# Altium schematic sheet Ethernet.SchDoc(Ethernet)
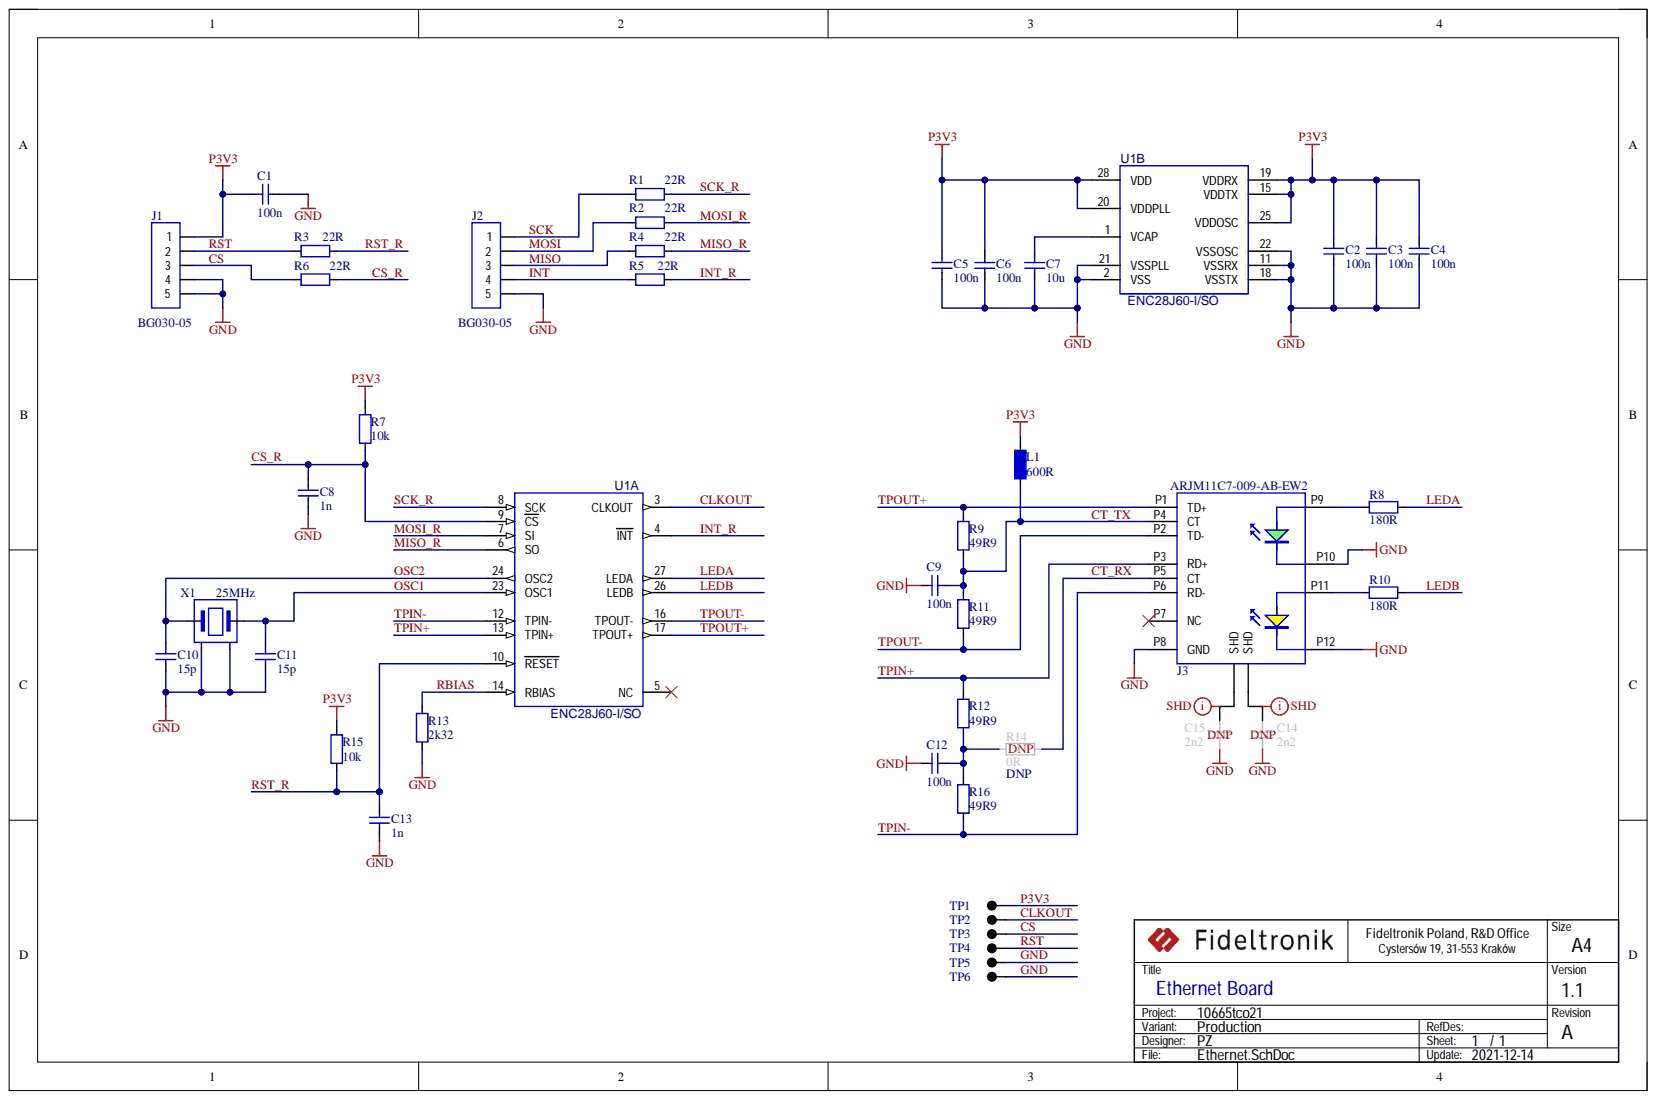
[diagram p0: Ethernet.SchDoc]

## Parts
C1  100n  [CAP_0603_R]
C2  100n  [CAP_0603_R]
C3  100n  [CAP_0603_R]
C4  100n  [CAP_0603_R]
C5  100n  [CAP_0603_R]
C6  100n  [CAP_0603_R]
C7  10u  [CAP_0603_R]
C8  1n  [CAP_0603_R]
C9  100n  [CAP_0603_R]
C10  15p  [CAP_0603_R]
C11  15p  [CAP_0603_R]
C12  100n  [CAP_0603_R]
C13  1n  [CAP_0603_R]
C14  2n2  [CAP_1812_H150_R]
C15  2n2  [CAP_1812_H150_R]
J1  BG030-05  [CON_HEADER_TMV_1X5_P254H704]
J2  BG030-05  [CON_HEADER_TMV_1X5_P254H704]
J3  ARJM11C7-009-AB-EW2  [CON_ABR_ARJM11C7-009-AB-EW2]
L1  600R  [IND_0603_R]
R1  22R  [RES_0402_R]
R2  22R  [RES_0402_R]
R3  22R  [RES_0402_R]
R4  22R  [RES_0402_R]
R5  22R  [RES_0402_R]
R6  22R  [RES_0402_R]
R7  10k  [RES_0603_R]
R8  180R  [RES_0603_R]
R9  49R9  [RES_0603_R]
R10  180R  [RES_0603_R]
R11  49R9  [RES_0603_R]
R12  49R9  [RES_0603_R]
R13  2k32  [RES_0603_R]
R14  0R  [RES_0603_R]
R15  10k  [RES_0603_R]
R16  49R9  [RES_0603_R]
TP1  PCB_TP_ICT  [PCB_TP_ICT_100]  (value not drawn)  (no BOM)
TP2  PCB_TP_ICT  [PCB_TP_ICT_100]  (value not drawn)  (no BOM)
TP3  PCB_TP_ICT  [PCB_TP_ICT_100]  (value not drawn)  (no BOM)
TP4  PCB_TP_ICT  [PCB_TP_ICT_100]  (value not drawn)  (no BOM)
TP5  PCB_TP_ICT  [PCB_TP_ICT_100]  (value not drawn)  (no BOM)
TP6  PCB_TP_ICT  [PCB_TP_ICT_100]  (value not drawn)  (no BOM)
U1  ENC28J60-I/SO  [PKG_SOP28_WIDE_R]  (parts A,B)
X1  25MHz  [OSC_EPS_TSX-3225_R]

## Nets
CLKOUT: TP2.1 U1.3(CLKOUT)
CS: J1.3 R6.1 TP3.1
CS_R: C8.2 R6.2 R7.2 U1.9(CS)
CT_RX: J3.P5(CT) R14.2
CT_TX: C9.2 J3.P4(CT) L1.1 R9.1 R11.1
GND: C1.1 C2.1 C3.1 C4.1 C5.1 C6.1 C7.1 C8.1 C9.1 C10.1 C11.1 C12.1 C13.1 C14.1 C15.1 J1.4 J1.5 J2.5 J3.P8(GND) J3.P10 J3.P12 R13.1 TP5.1 TP6.1 U1.2(VSS) U1.11(VSSRX) U1.18(VSSTX) U1.21(VSSPLL) U1.22(VSSOSC) X1.2 X1.4
INT: J2.4 R5.1
INT_R: R5.2 U1.4(INT)
LEDA: R8.2 U1.27(LEDA)
LEDB: R10.2 U1.26(LEDB)
MISO: J2.3 R4.1
MISO_R: R4.2 U1.6(SO)
MOSI: J2.2 R2.1
MOSI_R: R2.2 U1.7(SI)
OSC1: C11.2 U1.23(OSC1) X1.1
OSC2: C10.2 U1.24(OSC2) X1.3
P3V3: C1.2 C2.2 C3.2 C4.2 C5.2 C6.2 J1.1 L1.2 R7.1 R15.1 TP1.1 U1.15(VDDTX) U1.19(VDDRX) U1.20(VDDPLL) U1.25(VDDOSC) U1.28(VDD)
RBIAS: R13.2 U1.14(RBIAS)
RST: J1.2 R3.1 TP4.1
RST_R: C13.2 R3.2 R15.2 U1.10(RESET)
SCK: J2.1 R1.1
SCK_R: R1.2 U1.8(SCK)
TPIN+: J3.P3(RD+) R12.2 U1.13(TPIN+)
TPIN-: J3.P6(RD-) R16.2 U1.12(TPIN-)
TPOUT+: J3.P1(TD+) R9.2 U1.17(TPOUT+)
TPOUT-: J3.P2(TD-) R11.2 U1.16(TPOUT-)
NetC7_2: C7.2 U1.1(VCAP)
NetC12_2: C12.2 R12.1 R14.1 R16.1
NetC14_2: C14.2 J3.SH1(SHD)
NetC15_2: C15.2 J3.SH2(SHD)
NetJ3_P9: J3.P9 R8.1
NetJ3_P11: J3.P11 R10.1

Not connected: J3 P7(NC); U1 5(NC)

## Library data of the parts
C1-C6 C9 C12 = FT_C00015: CAP SMD 100n 10% 50V X7R (0603); Manufacturer: AVX; Manufacturer Part Number: 06035C104KAT2A; Database Table Name: CAPACITOR$; EBS: M1FT10130; SCH SYMBOL STATUS: Checked; Capacitance: 100n; Case: 0603; Component Kind: Standard; Dielectric: X7R; ITEM: FT_C00015; Library Name: FT_DB_LOCAL.DbLib; Mounting: S; STATUS: 2; Tolerance: 10%; Voltage: 50V
C7 = FT_C00371: CAP SMD 10u 10% 10V X5R (0603); Manufacturer: AVX; Manufacturer Part Number: 0603ZD106KAT2A; Database Table Name: CAPACITOR$; EBS: M1FT13760; SCH SYMBOL STATUS: Checked; Capacitance: 10u; Case: 0603; Component Kind: Standard; Dielectric: X5R; ITEM: FT_C00371; Library Name: FT_DB_LOCAL.DbLib; Mounting: S; STATUS: 0; Tolerance: 10%; Voltage: 10V
C8 C13 = FT_C00148: CAP SMD 1n 5% 50V C0G (0603); Manufacturer: AVX; Manufacturer Part Number: 06035A102JAT2A; Database Table Name: CAPACITOR$; EBS: M1FT11460; SCH SYMBOL STATUS: Checked; Capacitance: 1n; Case: 0603; Component Kind: Standard; Dielectric: C0G; ITEM: FT_C00148; Library Name: FT_DB_LOCAL.DbLib; Mounting: S; STATUS: 2; Tolerance: 5%; Voltage: 50V
C10 C11 = FT_C00084: CAP SMD 15p 5% 50V C0G (0603); Manufacturer: AVX; Manufacturer Part Number: 06035A150JAT2A; Database Table Name: CAPACITOR$; EBS: M1FT10820; SCH SYMBOL STATUS: Checked; Capacitance: 15p; Case: 0603; Component Kind: Standard; Dielectric: C0G; ITEM: FT_C00084; Library Name: FT_DB_LOCAL.DbLib; Mounting: S; STATUS: 2; Tolerance: 5%; Voltage: 50V
C14 C15 = FT_C00189: CAP SMD 2n2 10% 2000V X7R (1812); Manufacturer: AVX; Manufacturer Part Number: 1812GC222KAT1A; Database Table Name: CAPACITOR$; EBS: M1FT11880; SCH SYMBOL STATUS: Checked; Capacitance: 2n2; Case: 1812; Component Kind: Standard; Dielectric: X7R; ITEM: FT_C00189; Library Name: FT_DB_LOCAL.DbLib; Mounting: S; STATUS: 0; Tolerance: 10%; Voltage: 2000V
J1 J2 = FT_J00189: CONN THT / BOARD TO BOARD / PITCH 2,54mm / Vertical / Single Row / MALE / HEADER (1x5 PIN); Manufacturer: GCT; Manufacturer Part Number: BG030-05-A-0450-0300-N-G; Database Table Name: CONNECTOR$; Pitch (mm): 2,54; SCH SYMBOL STATUS: Checked; Component Kind: Standard; ITEM: FT_J00189; Library Name: FT_DB_LOCAL.DbLib; Mounting: T; Orientation: Vertical; Pins: 1x5; Properties: HEADER; Rows: Single Row; Side: MALE; STATUS: 0; Type: BOARD TO BOARD
J3 = FT_J00182: CONN THT / RJ-45 / Ethernet Connectors JACK W/MAG 1X1 100BASE-T; Manufacturer: ABRACON; Database Table Name: CONNECTOR$; SCH SYMBOL STATUS: new; Component Kind: Standard; ITEM: FT_J00182; Library Name: FT_DB_LOCAL.DbLib; Manufacturer Part Number: ARJM11C7-009-AB-EW2; Mounting: T; Properties: Ethernet Connectors JACK W/MAG 1X1 100BASE-T; STATUS: 0; Type: RJ-45
L1 = FT_F00025: FILTER SMD / FERRITE BEAD / 600 UM 100 MHz 200OHM 1GHz 500mA; Manufacturer: MURATA; Manufacturer Part Number: BLM18AG601SN1D; Database Table Name: FILTER$; SCH SYMBOL STATUS: Checked; Case: 0603; Component Kind: Standard; ITEM: FT_F00025; Library Name: FT_DB_LOCAL.DbLib; Mounting: S; Properties: 600 UM 100 MHz 200OHM 1GHz 500mA; STATUS: 0; Type: FERRITE BEAD
R1-R6 = FT_R00713: RES SMD 22R 1% 0.063W 100PPM (0402); Manufacturer: YAGEO; Manufacturer Part Number: RC0402FR-0722RL; Database Table Name: RESISTOR$; EBS: M1FT07160; SCH SYMBOL STATUS: Checked; Case: 0402; Component Kind: Standard; ITEM: FT_R00713; Library Name: FT_DB_LOCAL.DbLib; Mounting: S; Power: 0.063W; PPM: 100PPM; Resistance: 22R; STATUS: 0; Tolerance: 1%
R7 R15 = FT_R00098: RES SMD 10k 1% 0.1W 100PPM (0603); Manufacturer: ASJ; Manufacturer Part Number: CR16-1002-FL; Database Table Name: RESISTOR$; EBS: M1FT00970; SCH SYMBOL STATUS: Checked; Case: 0603; Component Kind: Standard; ITEM: FT_R00098; Library Name: FT_DB_LOCAL.DbLib; Mounting: S; Power: 0.1W; PPM: 100PPM; Resistance: 10k; STATUS: 2; Tolerance: 1%
R8 R10 = FT_R00236: RES SMD 180R 1% 0.1W 100PPM (0603); Manufacturer: ASJ; Manufacturer Part Number: CR16-1800-FL; Database Table Name: RESISTOR$; EBS: M1FT02350; SCH SYMBOL STATUS: Checked; Case: 0603; Component Kind: Standard; ITEM: FT_R00236; Library Name: FT_DB_LOCAL.DbLib; Mounting: S; Power: 0.1W; PPM: 100PPM; Resistance: 180R; STATUS: 2; Tolerance: 1%
R9 R11 R12 R16 = FT_R00595: RES SMD 49R9 1% 0.1W 100PPM (0603); Manufacturer: ASJ; Manufacturer Part Number: CR16-49R9-FL; Database Table Name: RESISTOR$; EBS: M1FT05940; SCH SYMBOL STATUS: Checked; Case: 0603; Component Kind: Standard; ITEM: FT_R00595; Library Name: FT_DB_LOCAL.DbLib; Mounting: S; Power: 0.1W; PPM: 100PPM; Resistance: 49R9; STATUS: 2; Tolerance: 1%
R13 = FT_R00783: RES SMD 2k32 1% 0.1W 100PPM (0603); Manufacturer: YAGEO; Manufacturer Part Number: RC0603FR-072K32L; Database Table Name: RESISTOR$; EBS: M1FT07890; SCH SYMBOL STATUS: Checked; Case: 0603; Component Kind: Standard; ITEM: FT_R00783; Library Name: FT_DB_LOCAL.DbLib; Mounting: S; Power: 0.1W; PPM: 100PPM; Resistance: 2k32; STATUS: 0; Tolerance: 1%
R14 = FT_R00940: RES SMD 0R 1% 0.1W 100PPM (0603); Manufacturer: YAGEO; Manufacturer Part Number: RC0603FR-070RL; Database Table Name: RESISTOR$; EBS: M1FT09520; SCH SYMBOL STATUS: Checked; Case: 0603; Component Kind: Standard; ITEM: FT_R00940; Library Name: FT_DB_LOCAL.DbLib; Mounting: S; Power: 0.1W; PPM: 100PPM; Resistance: 0R; STATUS: 0; Tolerance: 1%
TP1-TP6 = PCB_TP_ICT: SCH SYMBOL STATUS: Checked; Library Name: FT_Symbols_NO_BOM.SchLib
U1 = FT_U00255: IC SMD / ETHERNET CONTROLLER / Ethernet Controller 10 Base-T PHY SPI Interface (SOIC-28); Manufacturer: MICROCHIP; Database Table Name: IC$; SCH SYMBOL STATUS: New; Case: SOIC-28; Component Kind: Standard; ITEM: FT_U00255; Library Name: FT_DB_LOCAL.DbLib; Manufacturer Part Number: ENC28J60-I/SO; Mounting: S; Properties: Ethernet Controller 10 Base-T PHY SPI Interface; STATUS: 0; Type: ETHERNET CONTROLLER
X1 = FT_X00028: CRYSTAL SMD / 25MHz / 10PPM / 18p; Manufacturer: EPSON; Manufacturer Part Number: TSX-3225 25.0000MF10P-C3; Database Table Name: CRYSTAL$; SCH SYMBOL STATUS: Checked; Capacitance: 18p; Case: 3.2x2.5; Component Kind: Standard; Frequency: 25MHz; ITEM: FT_X00028; Library Name: FT_DB_LOCAL.DbLib; Mounting: S; PPM: 10PPM; PPM1: 10PPM; STATUS: 0
